# Altium schematic sheet FLASH.SchDoc
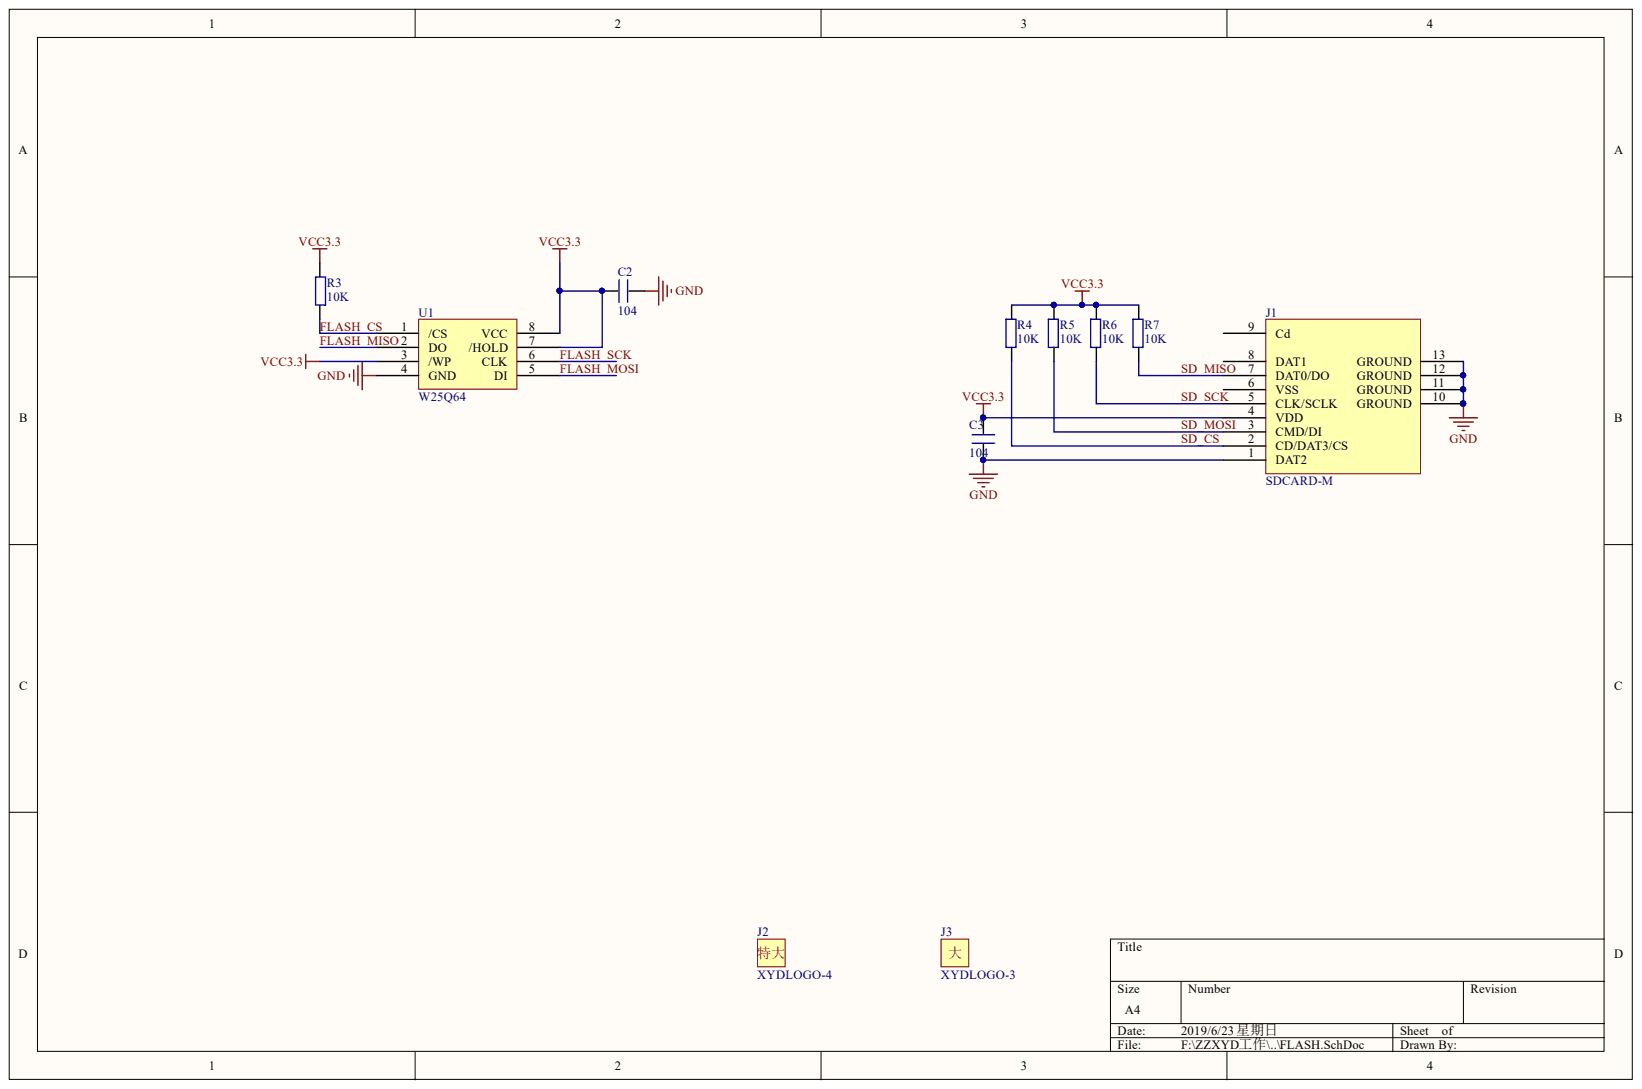
[diagram p0: FLASH.SchDoc]

## Parts
C2  value 104  [0805C]  (hidden comment: CAP)
C3  value 104  [0805C]  (hidden comment: CAP)
J1  SDCARD-M  [SDCARD-M]
J2  XYDLOGO-4  [XYDLOGO_4]
J3  XYDLOGO-3  [XYDLOGO_3]
R3  value 10K  [0805]  (hidden comment: RES)
R4  value 10K  [0805]  (hidden comment: RES)
R5  value 10K  [0805]  (hidden comment: RES)
R6  value 10K  [0805]  (hidden comment: RES)
R7  value 10K  [0805]  (hidden comment: RES)
U1  W25Q64  [SOIC8]

## Nets
GND: C2.1 C3.2 J1.1(DAT2) J1.10(GROUND) J1.11(GROUND) J1.12(GROUND) J1.13(GROUND) U1.4(GND)
VCC3.3: C2.2 C3.1 J1.4(VDD) R3.2 R4.1 R5.1 R6.1 R7.1 U1.3(/WP) U1.7(/HOLD) U1.8(VCC)
FLASH_CS: R3.1 U1.1(/CS)
FLASH_MISO: U1.2(DO)
FLASH_MOSI: U1.5(DI)
FLASH_SCK: U1.6(CLK)
SD_CS: J1.2(CD/DAT3/CS) R4.2
SD_MISO: J1.7(DAT0/DO) R7.2
SD_MOSI: J1.3(CMD/DI) R5.2
SD_SCK: J1.5(CLK/SCLK) R6.2

Not connected: J1 6(VSS) 8(DAT1) 9(Cd)

## Library data of the parts
J1 = SDCARD-M: TF卡槽; Component Kind: Standard; Library Name: Basic.SchLib
U1 = W25Q64: FLASH芯片; Component Kind: Standard; Library Name: 主控端最小系统.SCHLIB
C2 C3 = CAP: Component Kind: Standard; Description: CAP; Library Name: 主控端最小系统.SCHLIB
J2 = XYDLOGO-4: Component Kind: Standard; Library Name: 常用器件库.IntLib
J3 = XYDLOGO-3: Component Kind: Standard; Library Name: 常用器件库.IntLib
R3-R7 = RES: Component Kind: Standard; Description: RES; Library Name: 主控端最小系统.SCHLIB
